# Altium schematic sheet Power_supply.SchDoc
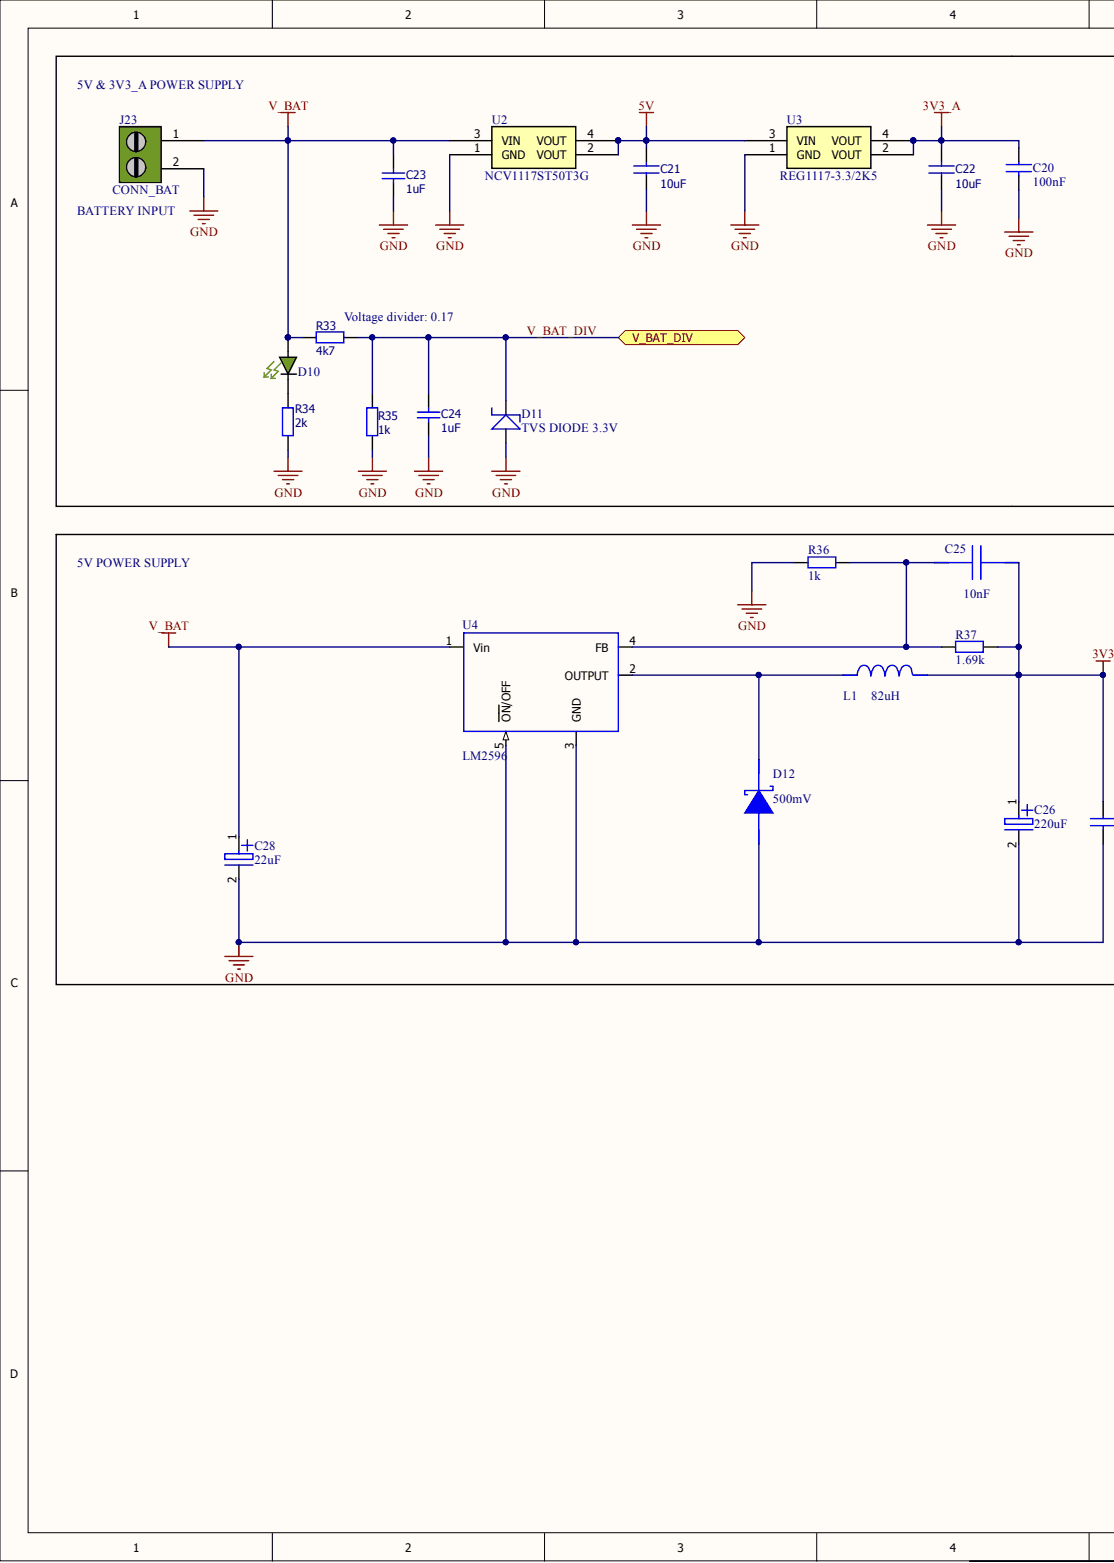
[diagram p0: Power_supply.SchDoc, left]
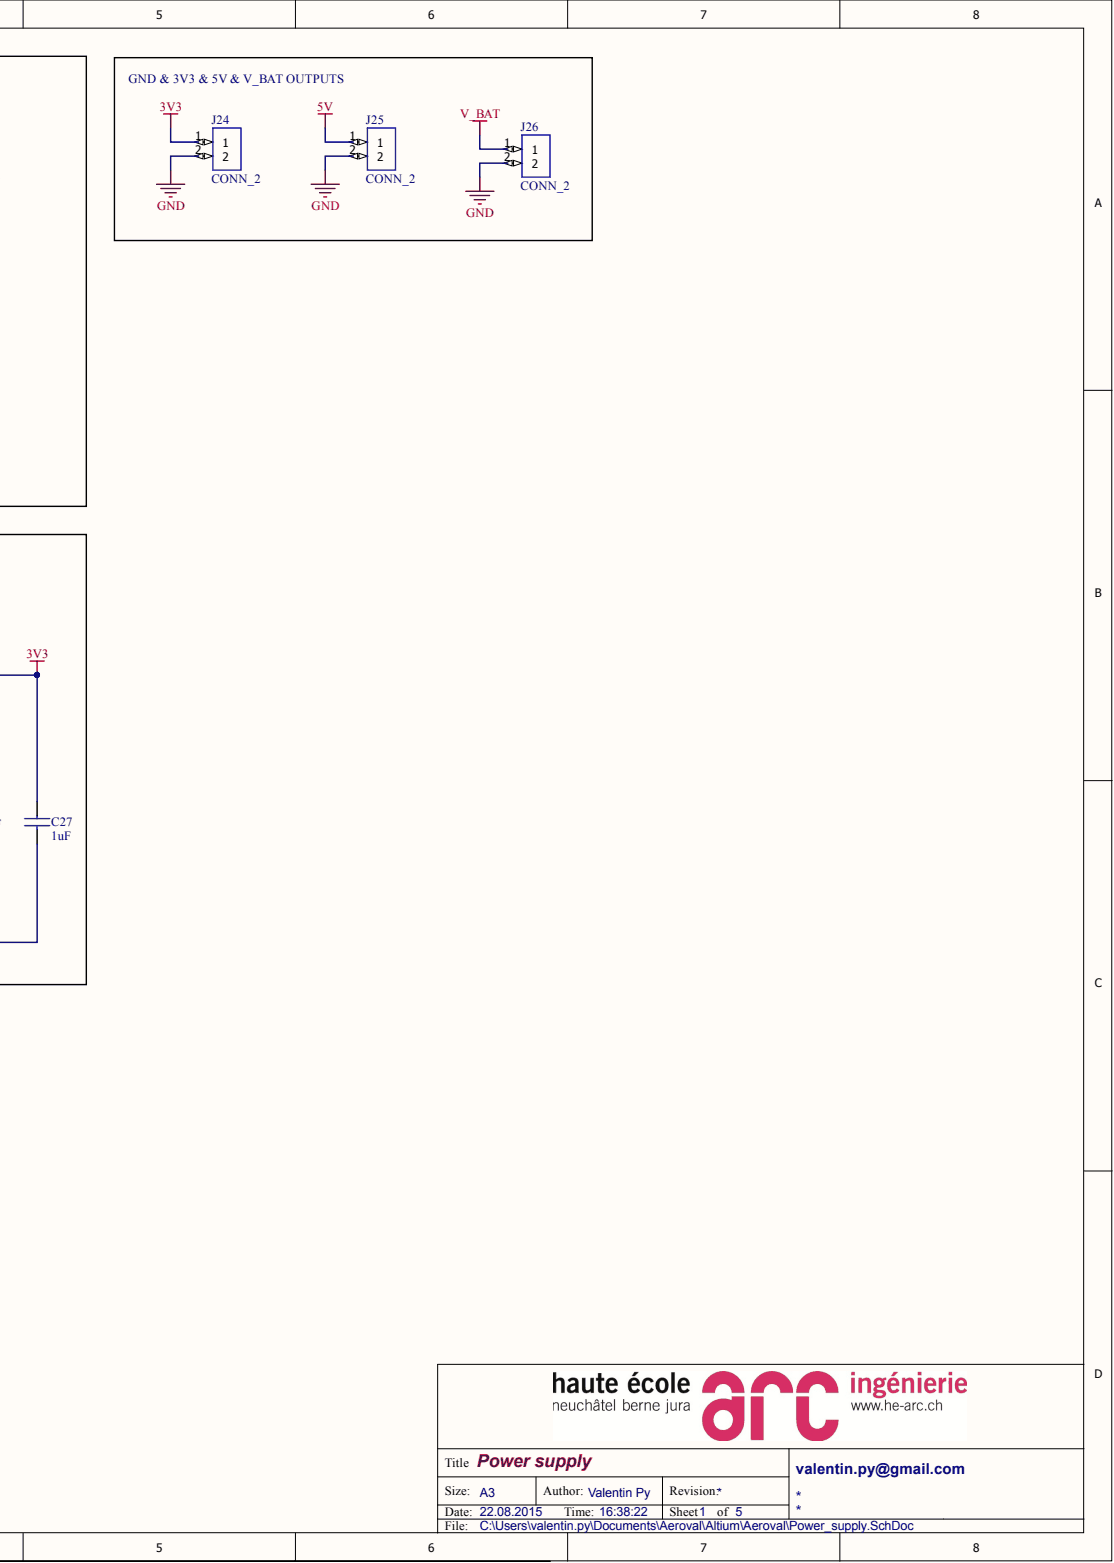
[diagram p1: Power_supply.SchDoc, right]

## Parts
C20  100nF  [080503]
C21  10uF  [080501]
C22  10uF  [080501]
C23  1uF  [080503]
C24  1uF  [080503]
C25  10nF  [080503]
C26  220uF  [1411]
C27  1uF  [080503]
C28  22uF  [2413]
D10  LED  [LED_0805 - GREEN]  (value not drawn)
D11  TVS DIODE 3.3V  [SOD-523]
D12  value 500mV  [SMA]  (hidden comment: B230A-13-F)
J23  CONN_BAT  [SL508_2C]
J24  CONN_2  [640454-2]
J25  CONN_2  [640454-2]
J26  CONN_2  [640454-2]
L1  82uH  [L1]
R33  4k7  [060301]
R34  2k  [060301]
R35  1k  [060301]
R36  1k  [060301]
R37  1.69k  [060301]
U2  NCV1117ST50T3G  [SOT223]
U3  REG1117-3.3/2K5  [SOT223]
U4  LM2596  [TO263]

## Ports
V_BAT_DIV

## Nets
3V3: C25.1 C26.1 C27.1 J24.1 L1.2 R37.2
3V3_A: C20.1 C22.2 U3.2(VOUT) U3.4(VOUT)
5V: C21.2 J25.1 U2.2(VOUT) U2.4(VOUT) U3.3(VIN)
GND: C20.2 C21.1 C22.1 C23.2 C24.2 C26.2 C27.2 C28.2 D11.1 D12.2 J23.2 J24.2 J25.2 J26.2 R34.1 R35.2 R36.1 U2.1(GND) U3.1(GND) U4.3(GND) U4.5(ON/OFF)
V_BAT: C23.1 C28.1 D10.A J23.1 J26.1 R33.2 U2.3(VIN) U4.1(Vin)
V_BAT_DIV: C24.1 D11.2 R33.1 R35.1 ; port V_BAT_DIV
NetC25_2: C25.2 R36.2 R37.1 U4.4(FB)
NetD10_C: D10.C R34.2
NetD12_1: D12.1 L1.1 U4.2(OUTPUT)

## Library data of the parts
C20 = CAPA: CAP CER 0.1UF 25V 10% X7R 0805; Component Kind: Standard; Supplier 1: Digi-Key; Supplier Part Number 1: 1276-1099-1-ND; Value: 100nF; Library Name: EISI.SchLib
C21 C22 = CAPA: CAP CER 10UF 16V 20% X6S 0805; Component Kind: Standard; Supplier 1: Digi-Key; Supplier Part Number 1: 445-7612-1-ND; Value: 10uF; Library Name: EISI.SchLib
C23 C24 = Cap Semi: CAP CER 1UF 25V 10% X7R 0805; Component Kind: Standard; Library Name: Miscellaneous Devices.IntLib; Signal Integrity: Cap Semi; Simulation: CAP; Supplier 1: Digi-Key; Supplier Part Number 1: 399-1168-1-ND; Value: 1uF
C25 = GRM216R71H103KA01D: CAP CER 10nF 50V 10% X7R 0805; Component Kind: Standard; Library Name: TI WEBENCH; Supplier 1: Digi-Key; Supplier Part Number 1: 399-1158-1-ND; Value: 10nF
C26 = CAPA_EL: CAP TANT 220UF 4V 20% 1411; Component Kind: Standard; Supplier 1: Digi-Key; Supplier Part Number 1: 399-4625-6-ND; Value: 220uF; Library Name: EISI.SchLib
C27 = CAPA: CAP CER 1UF 25V 10% X7R 0805; Component Kind: Standard; Supplier 1: Digi-Key; Supplier Part Number 1: 399-1168-1-ND; Value: 1uF; Library Name: EISI.SchLib
C28 = CAPA_EL: CAP TANT 22UF 25V 10% 2413; Component Kind: Standard; Supplier 1: Digi-Key; Supplier Part Number 1: 399-3746-1-ND; Value: 22uF; Library Name: EISI.SchLib
D10 = APT2012CGCK: LED 2X1.2MM 570NM GN WTR CLR SMD; Component Kind: Standard; Library Name: eDiode.SchLib; Supplier 1: Digi-Key; Supplier Part Number 1: 754-1127-1-ND
D11 = Zener: TVS DIODE 3.3VWM 8VC SOD523; Component Kind: Standard; Supplier 1: Digi-Key; Supplier Part Number 1: 641-1395-1-ND; Library Name: PalIncTest.SCHLIB
J23 = 282857-2: TERM BLOCK HDR 2POS R/A 5.08MM; Component Kind: Standard; Library Name: eConnector.SchLib; Supplier 1: Digi-Key; Supplier Part Number 1: 281-1279-ND; Value: 282857-2
J24-J26 = CONN_2: CONN HEADER 2.54mm 2; Component Kind: Standard; Supplier 1: Stock; Library Name: Aeroval.SchLib
L1 = SDR1307-820KL: Component Kind: Standard; Description: SDR1307-820KL; Library Name: TI WEBENCH; Supplier 1: Digi-Key; Supplier Part Number 1: SDR1307-820KLCT-ND; Value: 82uH
R33 = RES: RES 4.7K 1% 0603; Component Kind: Standard; Supplier 1: Digi-Key; Supplier Part Number 1: RMCF0603FT4K70CT-ND; Value: 4k7; Library Name: EISI.SchLib
R34 = RES: RES 2K 1% 0805; Component Kind: Standard; Supplier 1: Digi-Key; Supplier Part Number 1: P2.00KHCT-ND; Value: 2k; Library Name: EISI.SchLib
R35 R36 = RES: RES 1k 0805; Component Kind: Standard; Supplier 1: Digi-Key; Value: 1k; Library Name: EISI.SchLib
  R35: Supplier Part Number 1: P1.00KHDKR-ND
R37 = RES: RES 1.69K OHM 1% 1/8W 0805; Component Kind: Standard; Supplier 1: Digi-Key; Supplier Part Number 1: P1.69KHCT-ND; Value: 1.69k; Library Name: EISI.SchLib
U2 = NCV1117ST50T3G: Low Dropout (LDO) Regulators ANA 800mA LDO; Component Kind: Standard; Library Name: eIC.SchLib; Supplier 1: Digi-Key; Supplier Part Number 1: NCV1117ST50T3GOSCT-ND
U3 = NCV1117ST50T3G: IC REG LDO 3.3V 0.8A SOT223; Component Kind: Standard; Library Name: eIC.SchLib; Supplier 1: Digi-Key; Supplier Part Number 1: 296-21321-1-ND
U4 = LM2596: IC REG BUCK ADJ 3A TO263-5; Component Kind: Standard; Supplier 1: Digi-Key; Supplier Part Number 1: 296-35399-1-ND; Library Name: Aeroval.SchLib
D12 = B230A-13-F: Component Kind: Standard; Description: B230A-13-F; Library Name: TI WEBENCH; Supplier 1: Digi-Key; Supplier Part Number 1: B230A-FDICT-ND
